# Altium schematic sheet STM32F446RСT6_revA.SchDoc(STM32F446RСT6_revA)
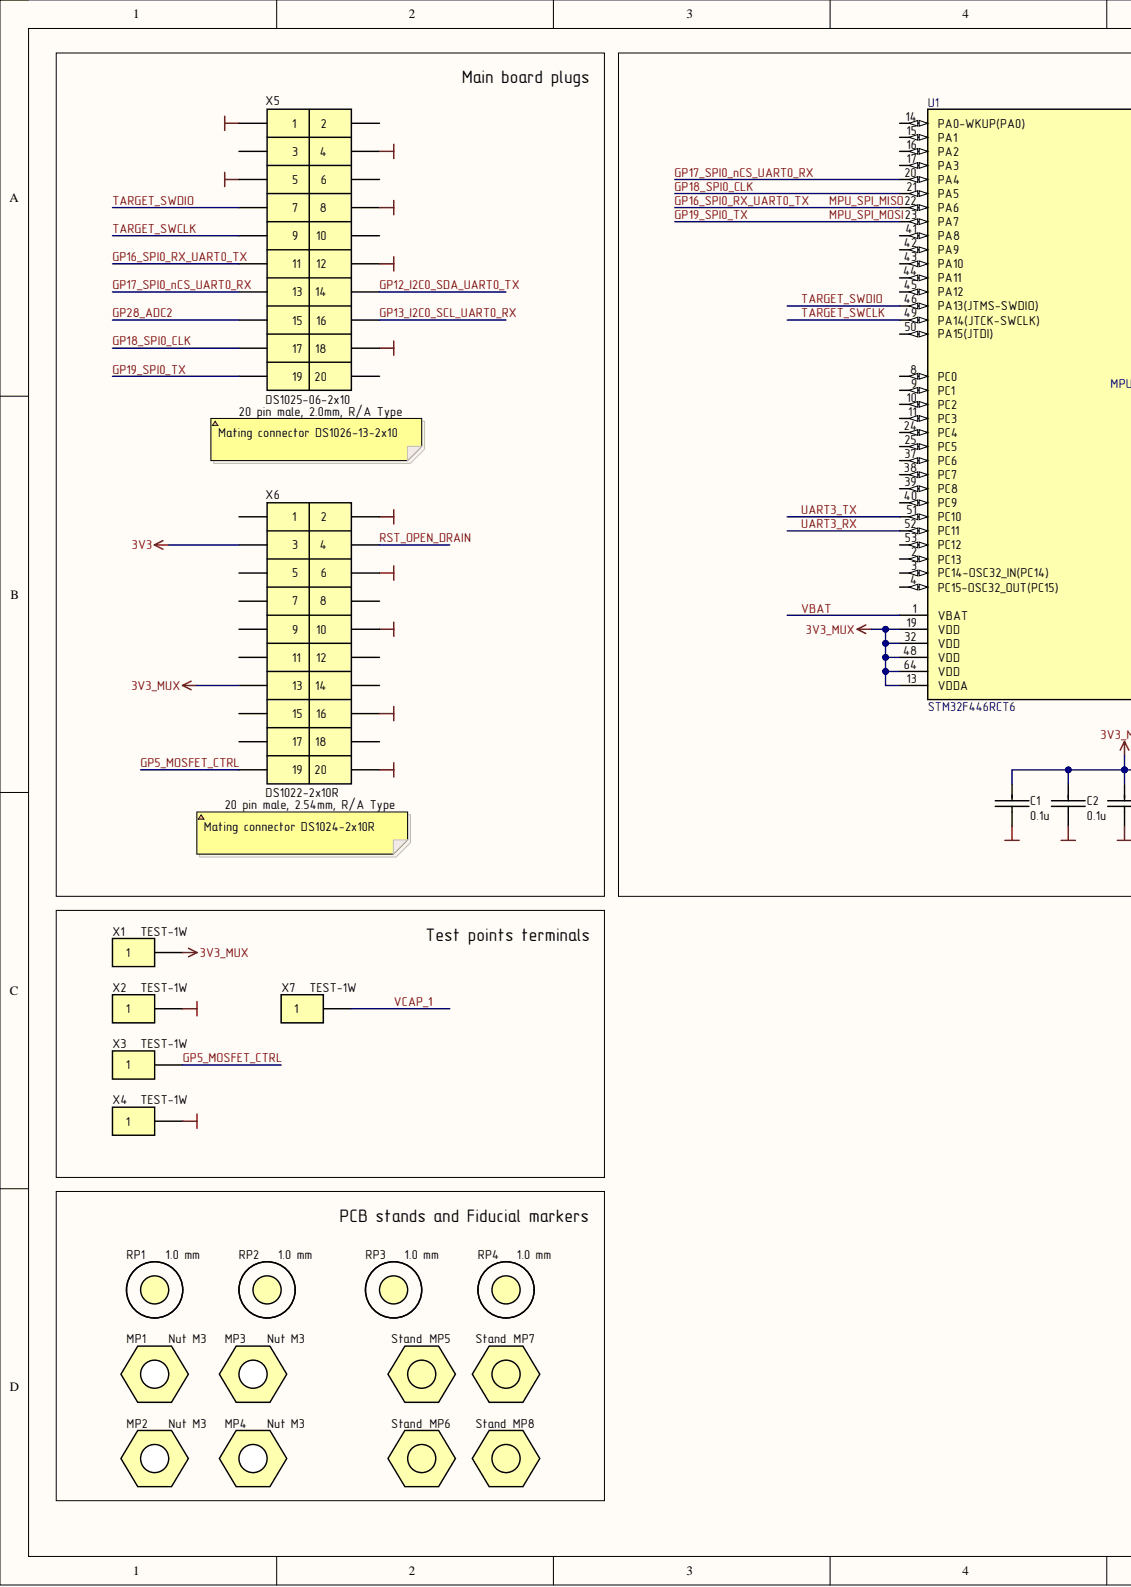
[diagram p0: STM32F446RСT6_revA.SchDoc, left]
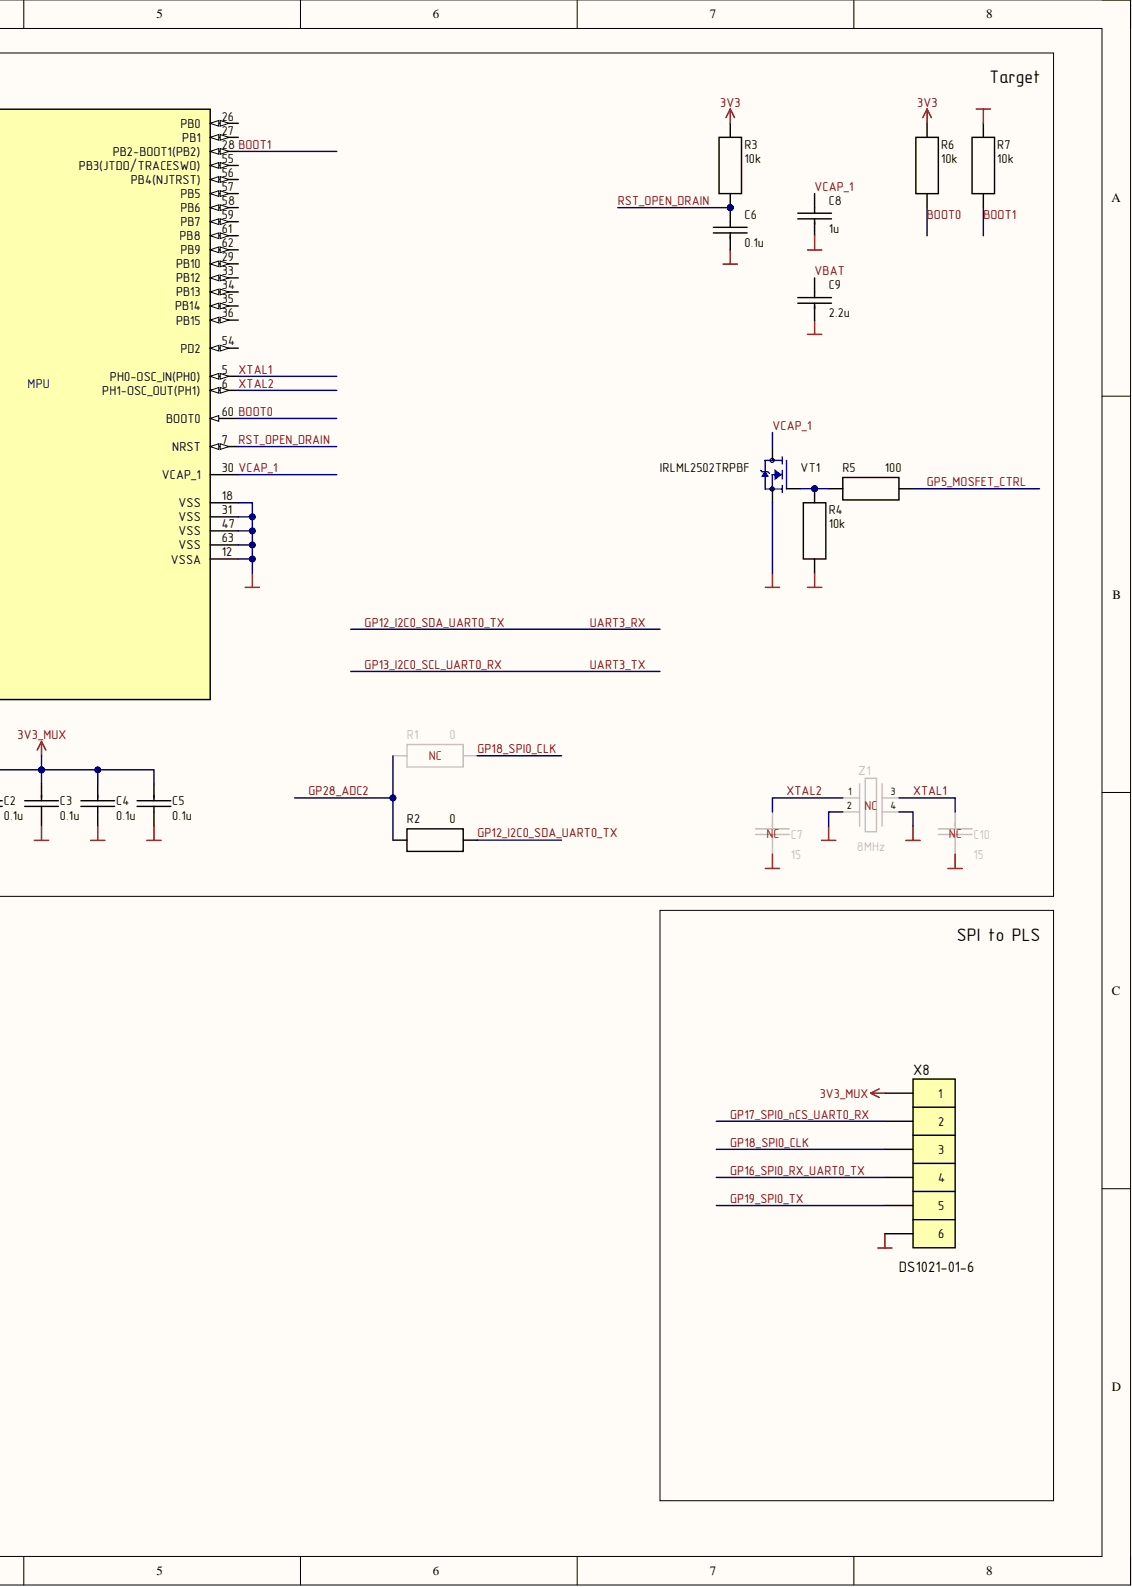
[diagram p1: STM32F446RСT6_revA.SchDoc, right]

## Parts
C1  value 0.1u  [CH0603C]  (hidden comment: Ceramic capacitor, 0603, 0.1u, ±10%, 50V, X7R, -55...+125°C)
C2  value 0.1u  [CH0603C]  (hidden comment: Ceramic capacitor, 0603, 0.1u, ±10%, 50V, X7R, -55...+125°C)
C3  value 0.1u  [CH0603C]  (hidden comment: Ceramic capacitor, 0603, 0.1u, ±10%, 50V, X7R, -55...+125°C)
C4  value 0.1u  [CH0603C]  (hidden comment: Ceramic capacitor, 0603, 0.1u, ±10%, 50V, X7R, -55...+125°C)
C5  value 0.1u  [CH0603C]  (hidden comment: Ceramic capacitor, 0603, 0.1u, ±10%, 50V, X7R, -55...+125°C)
C6  value 0.1u  [CH0603C]  (hidden comment: Ceramic capacitor, 0603, 0.1u, ±10%, 50V, X7R, -55...+125°C)
C7  value 15  [CH0603C]  (hidden comment: Ceramic capacitor, 0603, 15, ±10%, 100V, C0G, -55...+125°C)
C8  value 1u  [CH0603C]  (hidden comment: Ceramic capacitor, 0603, 2.2u, ±10%, 10V, X7R, -55...+125°C)
C9  value 2.2u  [CH0603C]  (hidden comment: Ceramic capacitor, 0603, 2.2u, ±10%, 10V, X7R, -55...+125°C)
C10  value 15  [CH0603C]  (hidden comment: Ceramic capacitor, 0603, 15, ±10%, 100V, C0G, -55...+125°C)
MP1  Nut M3  [Stand]  (mechanical)
MP2  Nut M3  [Stand]  (mechanical)
MP3  Nut M3  [Stand]  (mechanical)
MP4  Nut M3  [Stand]  (mechanical)
MP5  Stand  [Stand]
MP6  Stand  [Stand]
MP7  Stand  [Stand]
MP8  Stand  [Stand]
R1  value 0  [CH0603R]  (hidden comment: Resistor thick-film, 0603, 0, ±5%, 0.1W, -55...+125°C)
R2  value 0  [CH0603R]  (hidden comment: Resistor thick-film, 0603, 0, ±5%, 0.1W, -55...+125°C)
R3  value 10k  [CH0603R]  (hidden comment: Resistor thick-film, 0603, 10k, ±5%, 0.1W, -55...+125°C)
R4  value 10k  [CH0603R]  (hidden comment: Resistor thick-film, 0603, 10k, ±5%, 0.1W, -55...+125°C)
R5  value 100  [CH0603R]  (hidden comment: Resistor thick-film, 0603, 100, ±5%, 0.1W, -55...+125°C)
R6  value 10k  [CH0603R]  (hidden comment: Resistor thick-film, 0603, 10k, ±5%, 0.1W, -55...+125°C)
R7  value 10k  [CH0603R]  (hidden comment: Resistor thick-film, 0603, 10k, ±5%, 0.1W, -55...+125°C)
RP1  1.0 mm  [REPER-10]  (no BOM)
RP2  1.0 mm  [REPER-10]  (no BOM)
RP3  1.0 mm  [REPER-10]  (no BOM)
RP4  1.0 mm  [REPER-10]  (no BOM)
U1  STM32F446RCT6  [LQFP_64]
VT1  IRLML2502TRPBF  [SOT23]
X1  PartNumber: TEST-1W  [TEST-1W 1pin MULTICOMP]  (hidden comment: VDD)
X2  PartNumber: TEST-1W  [TEST-1W 1pin MULTICOMP]  (hidden comment: GND)
X3  GP5  PartNumber: TEST-1W  [TEST-1W 1pin MULTICOMP]
X4  PartNumber: TEST-1W  [TEST-1W 1pin MULTICOMP]  (hidden comment: GND)
X5  DS1025-06-2x10  [DS1025-06-2x10]
X6  DS1022-2x10R  [DS1022-2x10R]
X7  PartNumber: TEST-1W  [TEST-1W 1pin MULTICOMP]  (hidden comment: VCAP)
X8  DS1021-01-6  [DS1021-01-6  2.54]
Z1  8MHz  [OSC: 3225]

## Nets
3V3: R3.2 R6.2 X6.3
3V3_MUX: C1.1 C2.1 C3.1 C4.1 C5.1 U1.13(VDDA) U1.19(VDD) U1.32(VDD) U1.48(VDD) U1.64(VDD) X1.1 X6.13 X8.1
GND: C1.2 C2.2 C3.2 C4.2 C5.2 C6.1 C7.2 C8.1 C9.1 C10.2 R4.1 R7.2 U1.12(VSSA) U1.18(VSS) U1.31(VSS) U1.47(VSS) U1.63(VSS) VT1.2 X2.1 X4.1 X5.1 X5.4 X5.5 X5.8 X5.12 X5.18 X6.2 X6.6 X6.10 X6.16 X6.20 X8.6 Z1.2 Z1.4(NC)
BOOT0: R6.1 U1.60(BOOT0)
BOOT1: R7.1 U1.28(PB2-BOOT1(PB2))
GP5_MOSFET_CTRL: R5.1 X3.1 X6.19
GP12_I2C0_SDA_UART0_TX: R2.1 U1.52(PC11) X5.14
GP13_I2C0_SCL_UART0_RX: U1.51(PC10) X5.16
GP16_SPI0_RX_UART0_TX: U1.22(PA6) X5.11 X8.4
GP17_SPI0_nCS_UART0_RX: U1.20(PA4) X5.13 X8.2
GP18_SPI0_CLK: R1.1 U1.21(PA5) X5.17 X8.3
GP19_SPI0_TX: U1.23(PA7) X5.19 X8.5
GP28_ADC2: R1.2 R2.2 X5.15
RST_OPEN_DRAIN: C6.2 R3.1 U1.7(NRST) X6.4
TARGET_SWCLK: U1.49(PA14(JTCK-SWCLK)) X5.9
TARGET_SWDIO: U1.46(PA13(JTMS-SWDIO)) X5.7
VBAT: C9.2 U1.1(VBAT)
VCAP_1: C8.2 U1.30(VCAP_1) VT1.3 X7.1
XTAL1: C10.1 U1.5(PH0-OSC_IN(PH0)) Z1.3
XTAL2: C7.1 U1.6(PH1-OSC_OUT(PH1)) Z1.1
NetR4_2: R4.2 R5.2 VT1.1

Not connected: MP5 1; MP6 1; MP7 1; MP8 1; RP1 1; RP2 1; RP3 1; RP4 1; U1 2(PC13) 3(PC14-OSC32_IN(PC14)) 4(PC15-OSC32_OUT(PC15)) 8(PC0) 9(PC1) 10(PC2) 11(PC3) 14(PA0-WKUP(PA0)) 15(PA1) 16(PA2) 17(PA3) 24(PC4) 25(PC5) 26(PB0) 27(PB1) 29(PB10) 33(PB12) 34(PB13) 35(PB14) 36(PB15) 37(PC6) 38(PC7) 39(PC8) 40(PC9) 41(PA8) 42(PA9) 43(PA10) 44(PA11) 45(PA12) 50(PA15(JTDI)) 53(PC12) 54(PD2) 55(PB3(JTDO/TRACESWO)) 56(PB4(NJTRST)) 57(PB5) 58(PB6) 59(PB7) 61(PB8) 62(PB9); X5 2 3 6 10 20; X6 1 5 7 8 9 11 12 14 15 17 18

## Library data of the parts
C1-C6 = Capacitor: Manufacturer: Murata; PartNumber: GRM188R71H104K; Type: SMD; Component Kind: Standard; ComponentType: Ceramic capacitor; Description/Value: Ceramic capacitor, 0603, 0.1u, ±10%, 50V, X7R, -55...+125°C; HelpURL: ?; Library Name: Common Lib.SchLib; Package: 0603; Temperature: -55...+125°C; Tolerance: ±10%; TypeDielectric: X7R; Voltage: 50V
C7 C10 = Capacitor: Manufacturer: Murata; PartNumber: GRM1885C2A150J; Type: SMD; Component Kind: Standard; ComponentType: Ceramic capacitor; Description/Value: Ceramic capacitor, 0603, 15, ±10%, 100V, C0G, -55...+125°C; HelpURL: ?; Library Name: Common Lib.SchLib; Package: 0603; Temperature: -55...+125°C; Tolerance: ±10%; TypeDielectric: C0G; Voltage: 100V
C8 = Capacitor: Manufacturer: Murata; PartNumber: GCM188R71E105KA64D; Description/Value: Ceramic capacitor, 0603, 1u, ±10%, 25V, X7R, -55...+125°C; Type: SMD; Voltage: 25V; Component Kind: Standard; ComponentType: Ceramic capacitor; HelpURL: ?; Library Name: Common Lib.SchLib; Package: 0603; Temperature: -55...+125°C; Tolerance: ±10%; TypeDielectric: X7R
C9 = Capacitor: Manufacturer: Murata; PartNumber: GRM188R71A225KE15D; Type: SMD; Component Kind: Standard; ComponentType: Ceramic capacitor; Description/Value: Ceramic capacitor, 0603, 2.2u, ±10%, 10V, X7R, -55...+125°C; HelpURL: ?; Library Name: Common Lib.SchLib; Package: 0603; Temperature: -55...+125°C; Tolerance: ±10%; TypeDielectric: X7R; Voltage: 10V
MP1-MP4 = nut: PartNumber: Nut M3 DIN 934; Description/Value: Hexagon Nut M3 DIN 934; Library Name: Common Lib.SchLib
MP5-MP8 = stand: PartNumber: PCHSN-10; Description/Value: PCB brass stand, M3, 10mm; Component Kind: Standard; Library Name: Common Lib.SchLib
R1 R2 = Resistor: Manufacturer: Yageo; PartNumber: RC0603JR-070RL; Component Kind: Standard; ComponentType: Resistor thick-film; Description/Value: Resistor thick-film, 0603, 0, ±5%, 0.1W, -55...+125°C; HelpURL: https://datasheet.lcsc.com/lcsc/2009261810_YAGEO-RL0805FR-070R33L_C724024.pdf; Library Name: Common Lib.SchLib; Package: 0603; Power: 0.1W; Temperature: -55...+125°C; Tolerance: ±5%; Type: SMD
R3 R4 R6 R7 = Resistor: Manufacturer: Yageo; PartNumber: RC0603JR-0710KL; Component Kind: Standard; ComponentType: Resistor thick-film; Description/Value: Resistor thick-film, 0603, 10k, ±5%, 0.1W, -55...+125°C; HelpURL: https://datasheet.lcsc.com/lcsc/2009261810_YAGEO-RL0805FR-070R33L_C724024.pdf; Library Name: Common Lib.SchLib; Package: 0603; Power: 0.1W; Temperature: -55...+125°C; Tolerance: ±5%; Type: SMD
R5 = Resistor: Manufacturer: Yageo; PartNumber: RC0603JR-07100RL; Component Kind: Standard; ComponentType: Resistor thick-film; Description/Value: Resistor thick-film, 0603, 100, ±5%, 0.1W, -55...+125°C; HelpURL: https://datasheet.lcsc.com/lcsc/2009261810_YAGEO-RL0805FR-070R33L_C724024.pdf; Library Name: Common Lib.SchLib; Package: 0603; Power: 0.1W; Temperature: -55...+125°C; Tolerance: ±5%; Type: SMD
RP1-RP4 = REPER: Library Name: Common Lib.SchLib; Value: 1.0 mm
U1 = STM32F446RCT6: ARM Cortex-M4 32-bit MCU+FPU, 256 KB Flash, 128 KB Internal RAM, 50 I/Os, 64-Pin LQFP, -40 to 85 degC, Tray; D/A Converter: 2; FLASH Size (Prog) (kB): 256; I/Os (High Current): 50; Internal RAM Size (kB): 128; Operating Frequency(F) (Processor speed) (MHz): 180; Supply Voltage(Vcc) min (V): 1.7; Component Kind: Standard; ComponentLink1Description: Manufacturer URL; ComponentLink1URL: http://www.st.com/; ComponentLink2Description: Datasheet; ComponentLink2URL: http://www.st.com/st-web-ui/static/active/en/resource/technical/document/datasheet/DM00141306.pdf; Core: ARM Cortex-M4; DatasheetVersion: Rev. 6; Description/Value: ARM Cortex-M4 32-bit MCU+FPU, 256 KB Flash, 128 KB Internal RAM, 50 I/Os, 64-Pin LQFP, -40 to 85 degC, Tray; Library Name: Integrated_Library1.IntLib; Mounting Technology: Surface Mount; Package Description: LQFP64; Package Reference: 64-Pin Low-profile Quad Flat Package, Body 10 x 10 mm, Pitch 0.5 mm; Packing: Tray; PartNumber: STM32F446RCT6
VT1 = MOSFET N: RoHS Compliant | Industry-leading quality | Fast Switching | Low Profile _less than 1.1mm_ | SOT-23 Footprint; Description/Value: N-MOSFET Transistor, 20V, 4.2A, SOT-23-23; Component Kind: Standard; Library Name: Common Lib.SchLib; Package: SOT-23; PartNumber: IRLML2502TRPBF
X1-X4 X7 = 1-Connector: Description/Value: PCB Test Point Through Hole Mount; Component Kind: Standard; ComponentKind: PCB Test Point Through Hole Mount; Library Name: Common Lib.SchLib
X5 = 20-Connector: Description/Value: PLD Connector 20 pin, 2.0mm, Male, DS1025-06-2x10; Component Kind: Standard; ComponentKind: PLD Connector 20 pin, 2.0mm, Male; Library Name: Common Lib.SchLib; PartNumber: DS1025-06-2x10
X6 = 20-Connector: Description/Value: PLD Connector 20 pin, 2.54mm, Male, DS1022-2x10R; Component Kind: Standard; ComponentKind: PLD Connector 20 pin, 2.54mm, Male; Library Name: Common Lib.SchLib; PartNumber: DS1022-2x10R
X8 = 6-Connector: Description/Value: PLS Connector 6 pins, 2.54mm, Male; Component Kind: Standard; Library Name: Common Lib.SchLib; PartNumber: DS1021-01-6
Z1 = Crystal_3225_25MHz: PartNumber: KSE-7U08000MAB143ZA3; Description/Value: Crystal, SMD3225C4, 8MHz; Component Kind: Standard; Library Name: Common Lib.SchLib
